# Altium schematic sheet Arduino FPGA Top-Level Interface.SchDoc
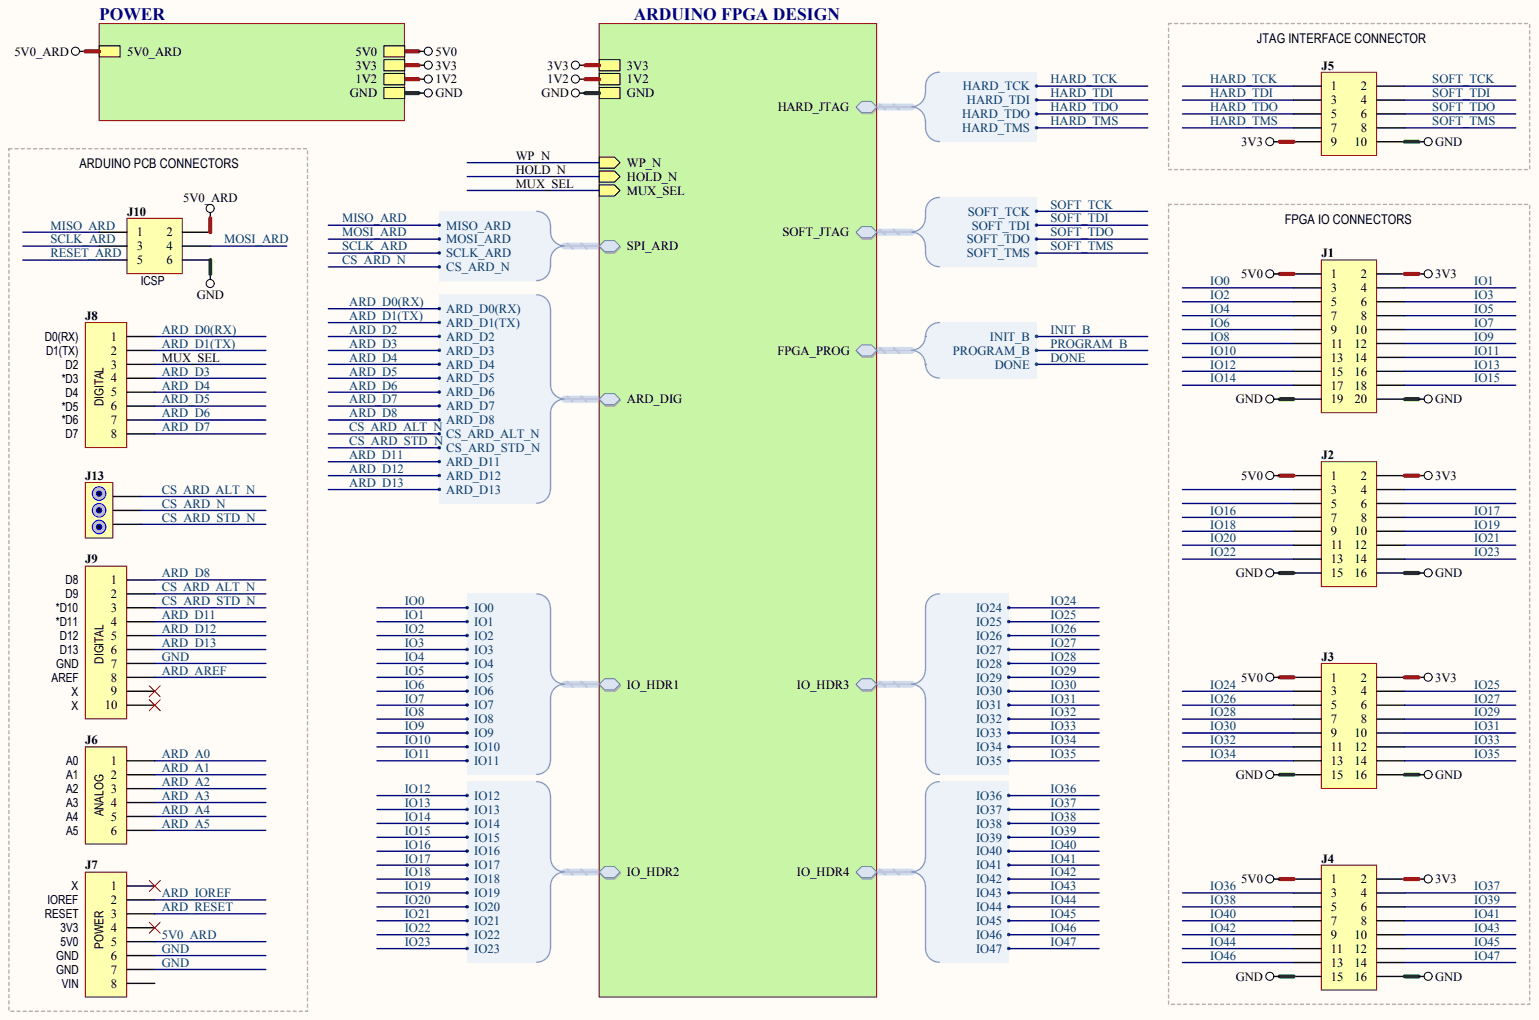
[diagram p0: Arduino FPGA Top-Level Interface.SchDoc]

## Parts
J1  Header 10X2  (value not drawn)
J2  Header 8X2  (value not drawn)
J3  Header 8X2  (value not drawn)
J4  Header 8X2  (value not drawn)
J5  Header 5X2  (value not drawn)
J6  Header 6  (value not drawn)
J7  Header 8  (value not drawn)
J8  Header 8  (value not drawn)
J9  Header 10  (value not drawn)
J10  Header 3X2  (value not drawn)
J13  3-WAY JUMPER  (value not drawn)

## Nets
3V3: J1.2 J2.2 J3.2 J4.2 J5.9
5V0: J1.1 J2.1 J3.1 J4.1
5V0_ARD: J7.5 J10.2
ARD_A0: J6.1
ARD_A1: J6.2
ARD_A2: J6.3
ARD_A3: J6.4
ARD_A4: J6.5
ARD_A5: J6.6
ARD_AREF: J9.8
ARD_D0(RX): J8.1
ARD_D1(TX): J8.2
ARD_D3: J8.4
ARD_D4: J8.5
ARD_D5: J8.6
ARD_D6: J8.7
ARD_D7: J8.8
ARD_D8: J9.1
ARD_D11: J9.4
ARD_D12: J9.5
ARD_D13: J9.6
ARD_IOREF: J7.2
ARD_RESET: J7.3
CS_ARD_ALT_N: J9.2 J13.3
CS_ARD_N: J13.2
CS_ARD_STD_N: J9.3 J13.1
GND: J1.19 J1.20 J2.15 J2.16 J3.15 J3.16 J4.15 J4.16 J5.10 J7.6 J7.7 J9.7 J10.6
HARD_TCK: J5.1
HARD_TDI: J5.3
HARD_TDO: J5.5
HARD_TMS: J5.7
IO0: J1.3
IO1: J1.4
IO2: J1.5
IO3: J1.6
IO4: J1.7
IO5: J1.8
IO6: J1.9
IO7: J1.10
IO8: J1.11
IO9: J1.12
IO10: J1.13
IO11: J1.14
IO12: J1.15
IO13: J1.16
IO14: J1.17
IO15: J1.18
IO16: J2.7
IO17: J2.8
IO18: J2.9
IO19: J2.10
IO20: J2.11
IO21: J2.12
IO22: J2.13
IO23: J2.14
IO24: J3.3
IO25: J3.4
IO26: J3.5
IO27: J3.6
IO28: J3.7
IO29: J3.8
IO30: J3.9
IO31: J3.10
IO32: J3.11
IO33: J3.12
IO34: J3.13
IO35: J3.14
IO36: J4.3
IO37: J4.4
IO38: J4.5
IO39: J4.6
IO40: J4.7
IO41: J4.8
IO42: J4.9
IO43: J4.10
IO44: J4.11
IO45: J4.12
IO46: J4.13
IO47: J4.14
MISO_ARD: J10.1
MOSI_ARD: J10.4
MUX_SEL: J8.3
RESET_ARD: J10.5
SCLK_ARD: J10.3
SOFT_TCK: J5.2
SOFT_TDI: J5.4
SOFT_TDO: J5.6
SOFT_TMS: J5.8
NetJ2_3: J2.3
NetJ2_4: J2.4
NetJ2_5: J2.5
NetJ2_6: J2.6
NetJ7_1: J7.1
NetJ7_4: J7.4
NetJ7_8: J7.8
NetJ9_9: J9.9
NetJ9_10: J9.10
1V2:
ARD_D2:
DONE:
HOLD_N:
INIT_B:
PROGRAM_B:
WP_N:

## Library data of the parts
J1-J10 J13 = (no symbol name)
  J1-J10: LatestRevisionDate: 17-Jul-2002; LatestRevisionNote: Re-released for DXP Platform.; Publisher: Altium Limited
